# Altium schematic sheet power.SchDoc
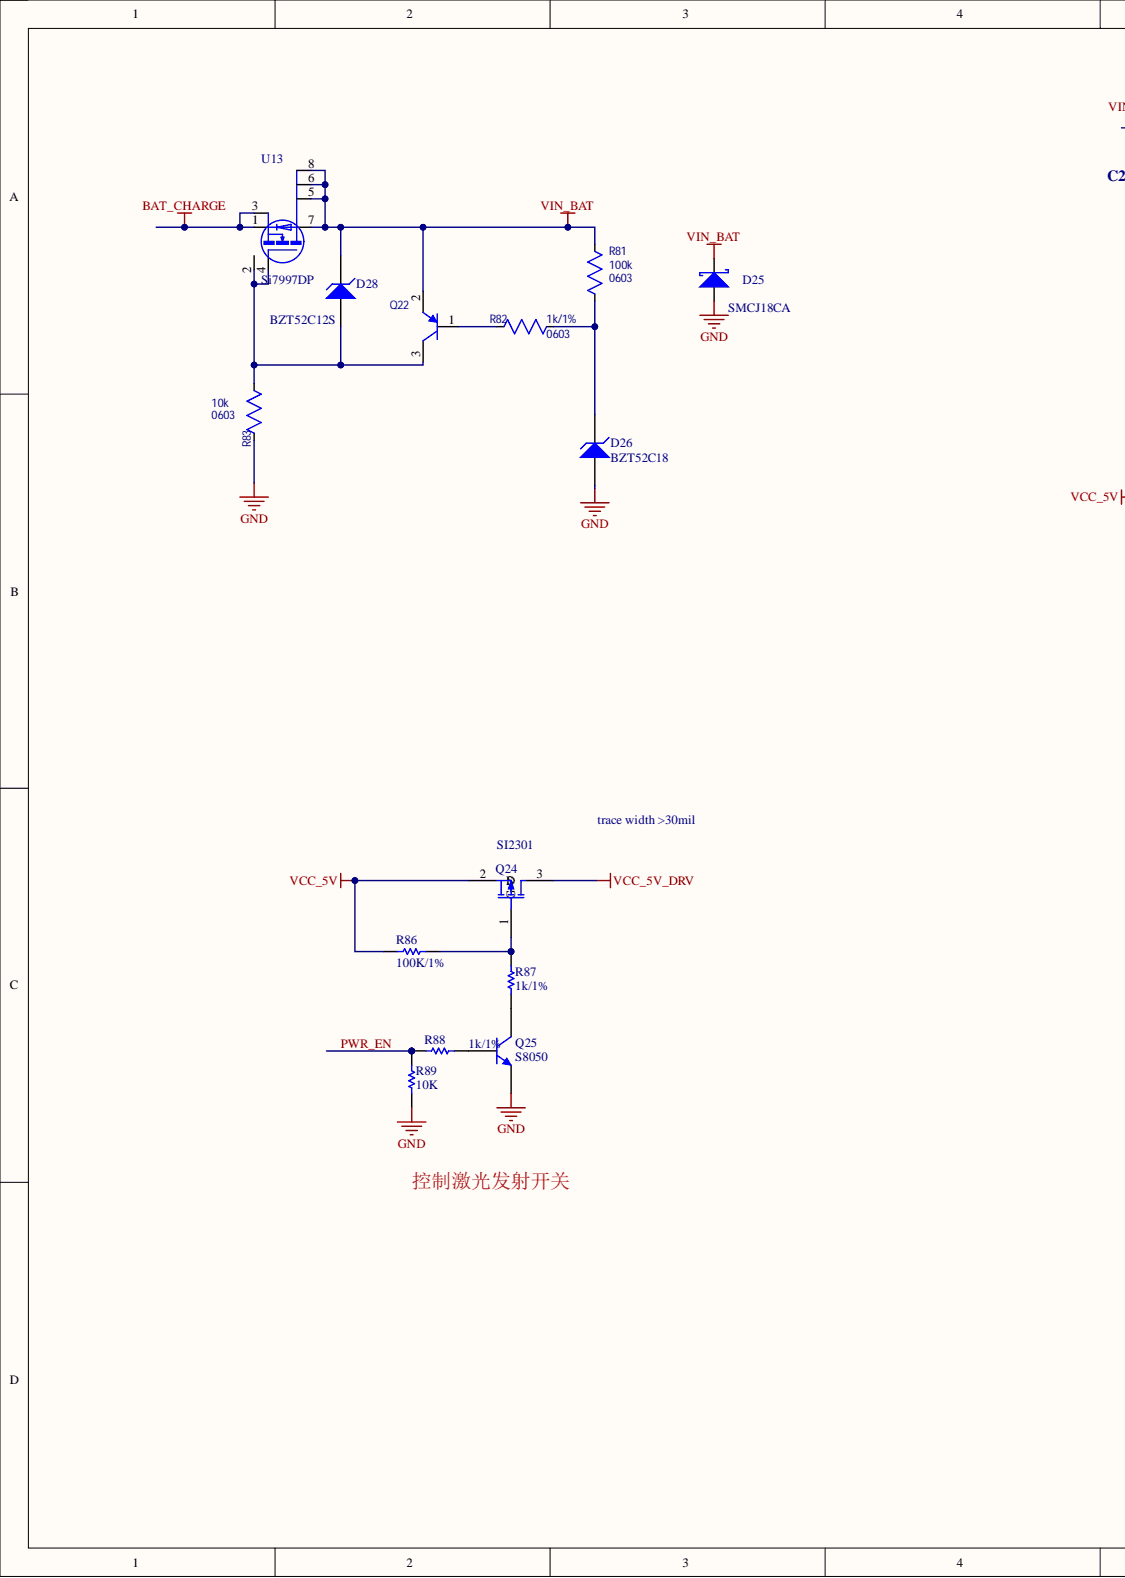
[diagram p0: power.SchDoc, left]
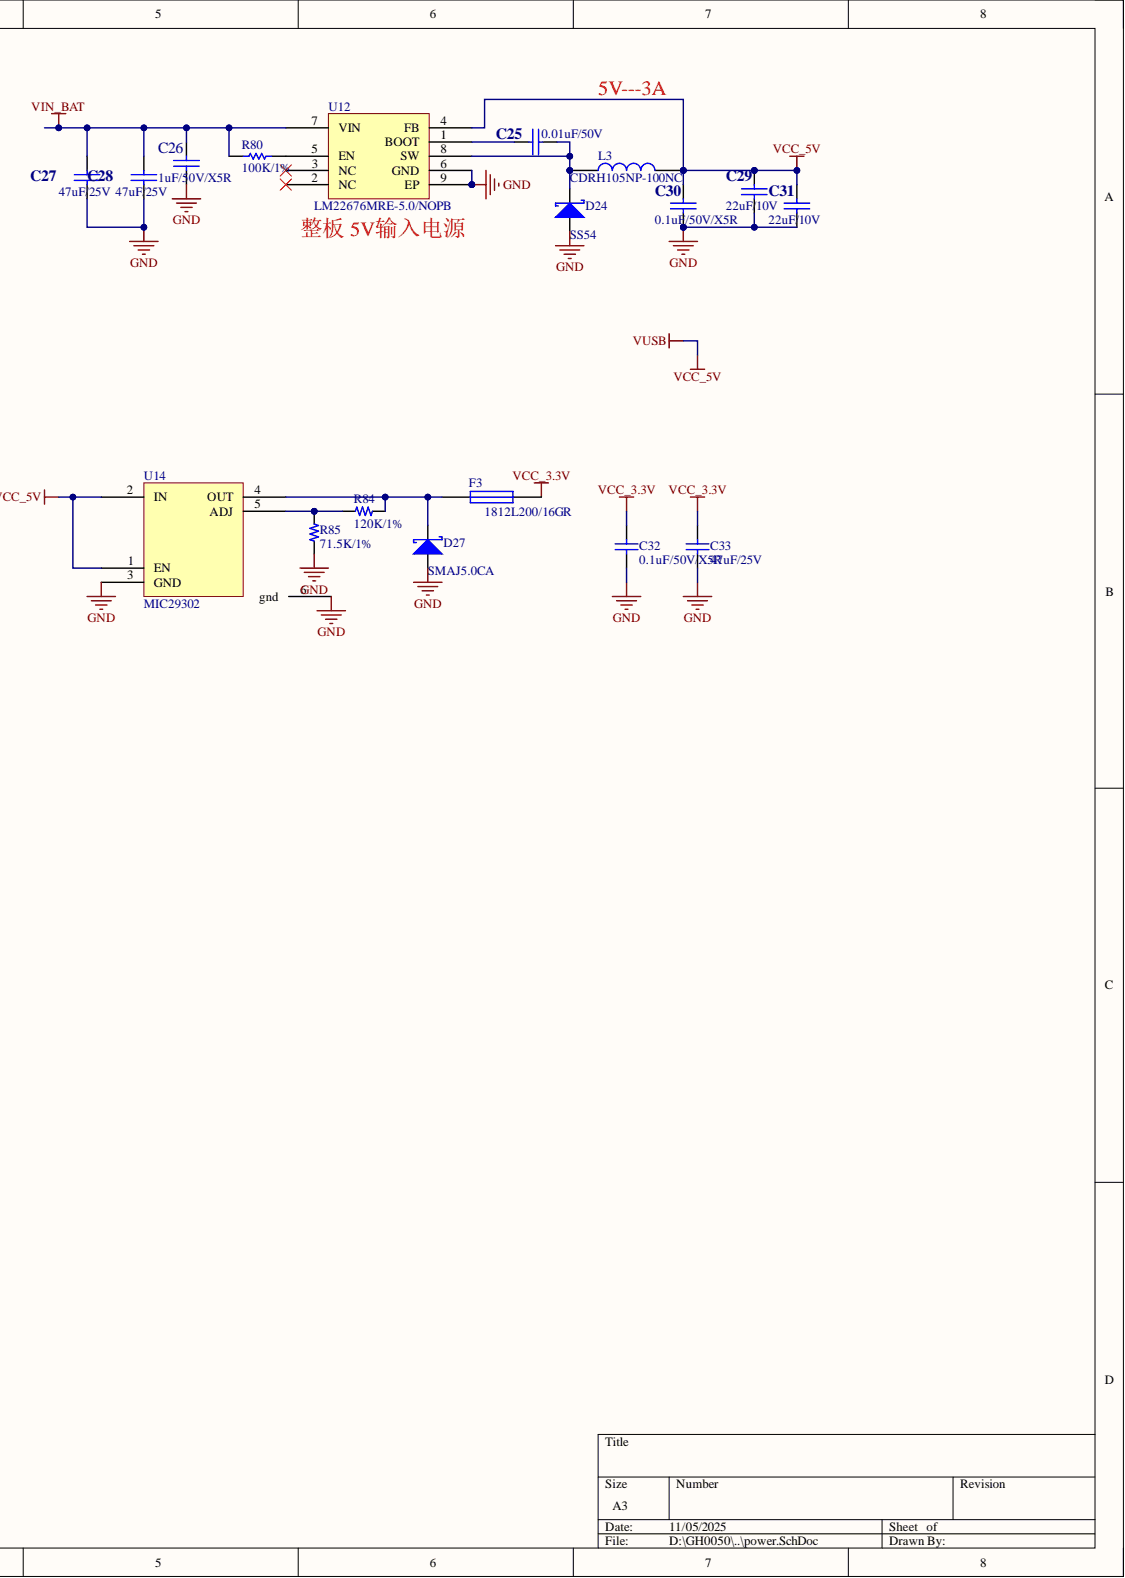
[diagram p1: power.SchDoc, right]

## Parts
C25  0.01uF/50V  [0603C]
C26  1uF/50V/X5R  [0603C]
C27  47uF/25V  [1210C]
C28  47uF/25V  [1210C]
C29  22uF/10V  [1210C]
C30  0.1uF/50V/X5R  [0603C]
C31  22uF/10V  [1210C]
C32  0.1uF/50V/X5R  [0603C]
C33  47uF/25V  [1206C]
D24  SS54  [SMC]
D25  SMCJ18CA  [SMC]
D26  BZT52C18  [sod123]
D27  SMAJ5.0CA  [SMA]
D28  BZT52C12S  [DIOD-SOD323-2_V]
F3  1812L200/16GR  [1812-F]
L3  CDRH105NP-100NC  [CDRH105]
Q22  MMBT4403-7-F  [SOT23-3]  (value not drawn)
Q24  SI2301  [SOT23-3]
Q25  S8050  [SOT23-3]
R80  100K/1%  [0603R]
R81  value 100k  Package: 0603  [0603R]  (hidden comment: 100K/1%)
R82  1k/1%  Package: 0603  [0603R]
R83  10k  Package: 0603  [0603R]
R84  120K/1%  [0603R]
R85  71.5K/1%  [0603R]
R86  100K/1%  [0603R]
R87  1k/1%  [0603R]
R88  1k/1%  [0603R]
R89  10K  [0603R]
U12  LM22676MRE-5.0/NOPB  [SOP127P600X168_HS-8N]
U13  Si7997DP  [PowerPAK SO-8]
U14  MIC29302  [TO228P1435X457-6N]

## Nets
BAT_CHARGE: U13.1 U13.3
GND: C26.1 C27.2 C28.2 C29.2 C30.2 C31.2 C32.1 C33.1 D24.1 D25.1 D26.1 D27.1 Q25.2 R83.2 R85.1 R89.2 U12.6(GND) U12.9(EP) U14.3(GND) U14.6(gnd)
VCC_3.3V: C32.2 C33.2 F3.2
VCC_5V: C29.1 C30.1 C31.1 L3.2 Q24.2 R86.2 U12.4(FB) U14.1(EN) U14.2(IN)
VCC_5V_DRV: Q24.3
VIN_BAT: C26.2 C27.1 C28.1 D25.2 D28.2 Q22.2 R80.2 R81.2 U12.7(VIN) U13.5 U13.6 U13.7 U13.8
PWR_EN: R88.2 R89.1
NetC25_1: C25.1 D24.2 L3.1 U12.8(SW)
NetC25_2: C25.2 U12.1(BOOT)
NetD26_2: D26.2 R81.1 R82.1
NetD27_2: D27.2 F3.1 R84.1 U14.4(OUT)
NetD28_1: D28.1 Q22.3 R83.1 U13.2 U13.4
NetQ22_1: Q22.1 R82.2
NetQ24_1: Q24.1 R86.1 R87.2
NetQ25_1: Q25.1 R88.1
NetQ25_3: Q25.3 R87.1
NetR80_1: R80.1 U12.5(EN)
NetR84_2: R84.2 R85.2 U14.5(ADJ)

Not connected: U12 2(NC) 3(NC)

## Library data of the parts
C25-C31 = CAP: Capacitor; Component Kind: Standard
C32 C33 = Cap: Capacitor; Value: 22nF; Component Kind: Standard; LatestRevisionDate: 17-Jul-2002; LatestRevisionNote: Re-released for DXP Platform.; Library Name: Miscellaneous Devices.IntLib; PackageDescription: Radial Cap, Thru-Hole; 2 Leads; 0.3 in Pin Spacing; PackageReference: RAD-0.3; Published: 8-Jun-2000; Signal Integrity: Cap; Simulation: CAP; Publisher: Altium Limited
D24 D25 D27 = DIODE S: Schottky Diode; Component Kind: Standard
D26 = BZT52C12S-7-F: Pd - Power Dissipation: 200mW; Unit Weight: 0.000173oz; Voltage Temperature Coefficient: 0.25mV/C; Voltage Tolerance: 5%; Vz - Zener Voltage: 12V; Zener Current: 5mA; Zz - Zener Impedance: 40Ohms; Component Kind: Standard; Minimum Operating Temperature: -65C; Mounting Style: SMD/SMT; Mounting Technology: SurfaceMount; Package / Case: SOD-323; Package Description: 2-Pin Surface Mount Device, Body 1.7 x 1.3 mm; Package Reference: SOD323-2; Package URL: http://www.vishay.com/docs/71192/71192.pdf; Packaging: Reel; Product Category: ZenerDiodes; RoHS: True; Series: BZT52C12; Termination Style: SMD/SMT; Value: BZT52C12S-7-F; Width: 1.4mm; Library Name: Bogen.SchLib
D28 = BZT52C12S-7-F: Surface Mount Zener Diode, 12 V, -65 to 150 degC, 2-Pin SOD323, RoHS, Tape and Reel; Manufacturer: DiodesIncorporated; Voltage Temperature Coefficient: 0.25mV/C; Voltage Tolerance: 5%; Vz - Zener Voltage: 12V; Zener Current: 5mA; Zz - Zener Impedance: 40Ohms; Component Kind: Standard; Value: BZT52C12S; Width: 1.4mm; Library Name: Bogen.SchLib
F3 = Fuse 1: Fuse; Component Kind: Standard; LatestRevisionDate: 17-Jul-2002; LatestRevisionNote: Re-released for DXP Platform.; Library Name: Miscellaneous Devices.IntLib; PackageDescription: Thru-Hole; 2 Leads; PackageReference: PIN-W2/E2.8; Published: 8-Jun-2000; Simulation: FUSE; Publisher: Altium Limited
L3 = INDUCTOR: Component Kind: Standard
Q22 = OEM_Diodes_MMBT4403: Component Kind: Standard; Value: MMBT4403-7-F; Library Name: Karl.SchLib
Q24 = MOSFET-P: P-Channel MOSFET; Component Kind: Standard; LatestRevisionDate: 17-Jul-2002; LatestRevisionNote: Re-released for DXP Platform.; Library Name: Miscellaneous Devices.IntLib; PackageReference: E3; PackageVersion: 1995/1996; Published: 8-Jun-2000; Simulation: PMOS; Publisher: Altium Limited
Q25 = NPN: NPN Bipolar Transistor; Code_JEDEC: TO-226-AA; Component Kind: Standard; LatestRevisionDate: 17-Jul-2002; LatestRevisionNote: Re-released for DXP Platform.; Library Name: Miscellaneous Devices.IntLib; PackageReference: TO-226; Published: 8-Jun-2000; Signal Integrity: NPN; Simulation: NPN; Publisher: Altium Limited
R80 R86-R89 = Res1: Resistor; Component Kind: Standard; LatestRevisionDate: 17-Jul-2002; LatestRevisionNote: Re-released for DXP Platform.; Library Name: Miscellaneous Devices.IntLib; PackageReference: AXIAL-0.3; Published: 8-Jun-2000; Signal Integrity: Res; Simulation: RESISTOR; Publisher: Altium Limited
R81 = Resistor_0603: PartNumber: RC0603FR-0716K9L; Power Rating: [power]; TempCo: [tempco]; Tolerance: tol; Component Kind: Standard; SupplierDescription: RES SMD 16.9K OHM 1% 1/10W 0603; Library Name: Karl.SchLib
R82 R83 = Resistor_0603: PartNumber: RC0603FR-071KL; Power Rating: [power]; TempCo: [tempco]; Tolerance: tol; Component Kind: Standard; SupplierDescription: RES SMD 1K OHM 1% 1/10W 0603; Library Name: Karl.SchLib
  R82: Value: 1k/1%
  R83: Value: 10k
R84 R85 = R: Component Kind: Standard; Description: R; Library Name: JRJ_1.SCHLIB
U12 = LM22676MR-5.0: Component Kind: Standard; Library Name: Schlib1.SchLib
U13 = Si7997DP: Sia430DJ; Component Kind: Standard; Library Name: Schlib_20110825.schlib
U14 = MIC29302: Component Kind: Standard; Library Name: power.SCHLIB
